# Altium schematic sheet Sheet1.SchDoc
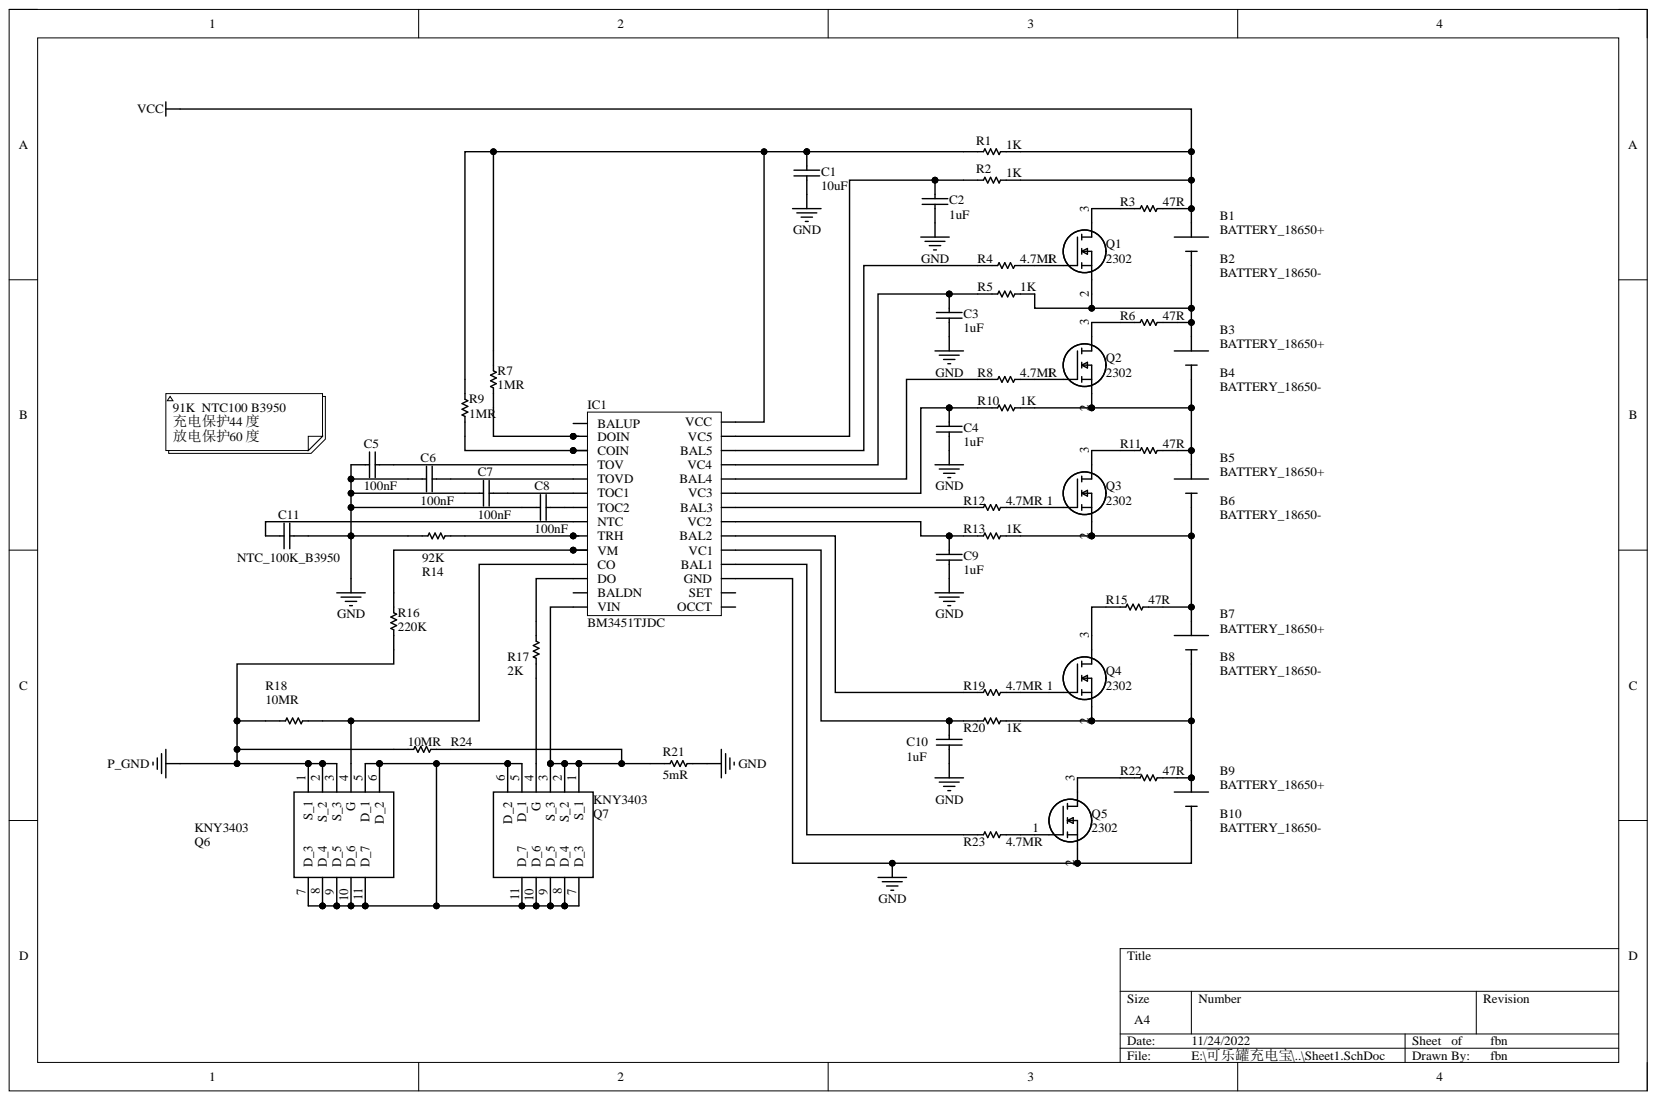
[diagram p0: Sheet1.SchDoc]

## Parts
B1  BATTERY_18650+  [BATTERY_18650+]
B2  BATTERY_18650-  [BATTERY_18650-]
B3  BATTERY_18650+  [BATTERY_18650+]
B4  BATTERY_18650-  [BATTERY_18650-]
B5  BATTERY_18650+  [BATTERY_18650+]
B6  BATTERY_18650-  [BATTERY_18650-]
B7  BATTERY_18650+  [BATTERY_18650+]
B8  BATTERY_18650-  [BATTERY_18650-]
B9  BATTERY_18650+  [BATTERY_18650+]
B10  BATTERY_18650-  [BATTERY_18650-]
C1  10uF  [0402C]
C2  1uF  [0402C]
C3  1uF  [0402C]
C4  1uF  [0402C]
C5  100nF  [0402C]
C6  100nF  [0402C]
C7  100nF  [0402C]
C8  100nF  [0402C]
C9  1uF  [0402C]
C10  1uF  [0402C]
C11  NTC_100K_B3950  [1210C]
IC1  BM3451TJDC  [SOP65P640X120-28N]
Q1  2302  [SOT95P280X125-3N]
Q2  2302  [SOT95P280X125-3N]
Q3  2302  [SOT95P280X125-3N]
Q4  2302  [SOT95P280X125-3N]
Q5  2302  [SOT95P280X125-3N]
Q6  KNY3403  [DFN5x6]
Q7  KNY3403  [DFN5x6]
R1  1K  [0402R]
R2  1K  [0402R]
R3  47R  [1812R]
R4  4.7MR  [0402R]
R5  1K  [0402R]
R6  47R  [1812R]
R7  1MR  [0402R]
R8  4.7MR  [0402R]
R9  1MR  [0402R]
R10  1K  [0402R]
R11  47R  [1812R]
R12  4.7MR  [0402R]
R13  1K  [0402R]
R14  92K  [0402R]
R15  47R  [1812R]
R16  220K  [0402R]
R17  2K  [0402R]
R18  10MR  [0805R]
R19  4.7MR  [0402R]
R20  1K  [0402R]
R21  5mR  [2512R]
R22  47R  [1812R]
R23  4.7MR  [0402R]
R24  10MR  [0805R]

## Nets
GND: B10.1 C1.1 C2.1 C3.1 C4.1 C5.2 C6.2 C7.2 C8.2 C9.1 C10.1 C11.2 IC1.17(GND) Q5.2 R14.1 R21.2
P_GND: Q6.1(S_1) Q6.2(S_2) Q6.3(S_3) R16.2 R18.1 R24.1
VCC: B1.1 R1.2 R2.2 R3.2
NetB2_1: B2.1 B3.1 Q1.2 R5.2 R6.2
NetB4_1: B4.1 B5.1 Q2.2 R10.2 R11.2
NetB6_1: B6.1 B7.1 Q3.2 R13.2 R15.2
NetB8_1: B8.1 B9.1 Q4.2 R20.2 R22.2
NetC1_2: C1.2 IC1.28(VCC) R1.1 R7.2 R9.2
NetC2_2: C2.2 IC1.27(VC5) R2.1
NetC3_2: C3.2 IC1.25(VC4) R5.1
NetC4_2: C4.2 IC1.23(VC3) R10.1
NetC5_1: C5.1 IC1.4(TOV)
NetC6_1: C6.1 IC1.5(TOVD)
NetC7_1: C7.1 IC1.6(TOC1)
NetC8_1: C8.1 IC1.7(TOC2)
NetC9_2: C9.2 IC1.21(VC2) R13.1
NetC10_2: C10.2 IC1.19(VC1) R20.1
NetC11_1: C11.1 IC1.8(NTC)
NetIC1_2: IC1.2(DOIN) R7.1
NetIC1_3: IC1.3(COIN) R9.1
NetIC1_9: IC1.9(TRH) R14.2
NetIC1_10: IC1.10(VM) R16.1
NetIC1_11: IC1.11(CO) Q6.4(G) R18.2
NetIC1_12: IC1.12(DO) R17.2
NetIC1_14: IC1.14(VIN) Q7.1(S_1) Q7.2(S_2) Q7.3(S_3) R21.1 R24.2
NetIC1_18: IC1.18(BAL1) R23.1
NetIC1_20: IC1.20(BAL2) R19.1
NetIC1_22: IC1.22(BAL3) R12.1
NetIC1_24: IC1.24(BAL4) R8.1
NetIC1_26: IC1.26(BAL5) R4.1
NetQ1_1: Q1.1 R4.2
NetQ1_3: Q1.3 R3.1
NetQ2_1: Q2.1 R8.2
NetQ2_3: Q2.3 R6.1
NetQ3_1: Q3.1 R12.2
NetQ3_3: Q3.3 R11.1
NetQ4_1: Q4.1 R19.2
NetQ4_3: Q4.3 R15.1
NetQ5_1: Q5.1 R23.2
NetQ5_3: Q5.3 R22.1
NetQ6_5: Q6.5(D_1) Q6.6(D_2) Q6.7(D_3) Q6.8(D_4) Q6.9(D_5) Q6.10(D_6) Q6.11(D_7) Q7.5(D_1) Q7.6(D_2) Q7.7(D_3) Q7.8(D_4) Q7.9(D_5) Q7.10(D_6) Q7.11(D_7)
NetQ7_4: Q7.4(G) R17.1

Not connected: IC1 1(BALUP) 13(BALDN) 15(OCCT) 16(SET)

## Library data of the parts
C1-C11 = CAP: 无极性电容; Component Kind: Standard; Library Name: 1-电容.IntLib
IC1 = BM3451: 电池管理芯片; Component Kind: Standard; Library Name: SamacSys.SchLib
Q1-Q5 = AO3400: MOSFET (N-Channel); Manufacturer_Name: Alpha & Omega Semiconductors; Arrow Part Number: AO3400; Arrow Price/Stock: https://www.arrow.com/en/products/ao3400/alpha-and-omega-semiconductor; Component Kind: Standard; Datasheet Link: http://aosmd.com/res/data_sheets/AO3400.pdf; Height: 1.25mm; Library Name: SamacSys.SchLib; Manufacturer_Part_Number: AO3400
Q6 Q7 = CMS70N04H8-HF: MOSFET (N-Channel); Manufacturer_Name: Comchip Technology; Component Kind: Standard; Datasheet Link: https://www.comchiptech.com/admin/files/product/12034_20190327120058.PDF; Height: 1.25mm; Library Name: SamacSys.SchLib; Manufacturer_Part_Number: CMS70N04H8-HF; Mouser Part Number: 750-CMS70N04H8-HF; Mouser Price/Stock: https://www.mouser.co.uk/ProductDetail/Comchip-Technology/CMS70N04H8-HF?qs=Zz7%252BYVVL6bH0Kh4xkHf10Q%3D%3D
R1-R24 = RES: Component Kind: Standard
  R1 R2 R4 R5 R7-R10 R12-R14 R16-R21 R23 R24: Library Name: 9-电阻.IntLib
  R3 R6 R11 R15 R22: Library Name: 24-电阻.IntLib
B1 B3 B5 B7 B9 = BATTERY_18650+: Component Kind: Standard; Library Name: SamacSys.SchLib
B2 B4 B6 B8 B10 = BATTERY_18650-: Component Kind: Standard; Library Name: SamacSys.SchLib
